# Altium schematic sheet RF.SchDoc(RF)
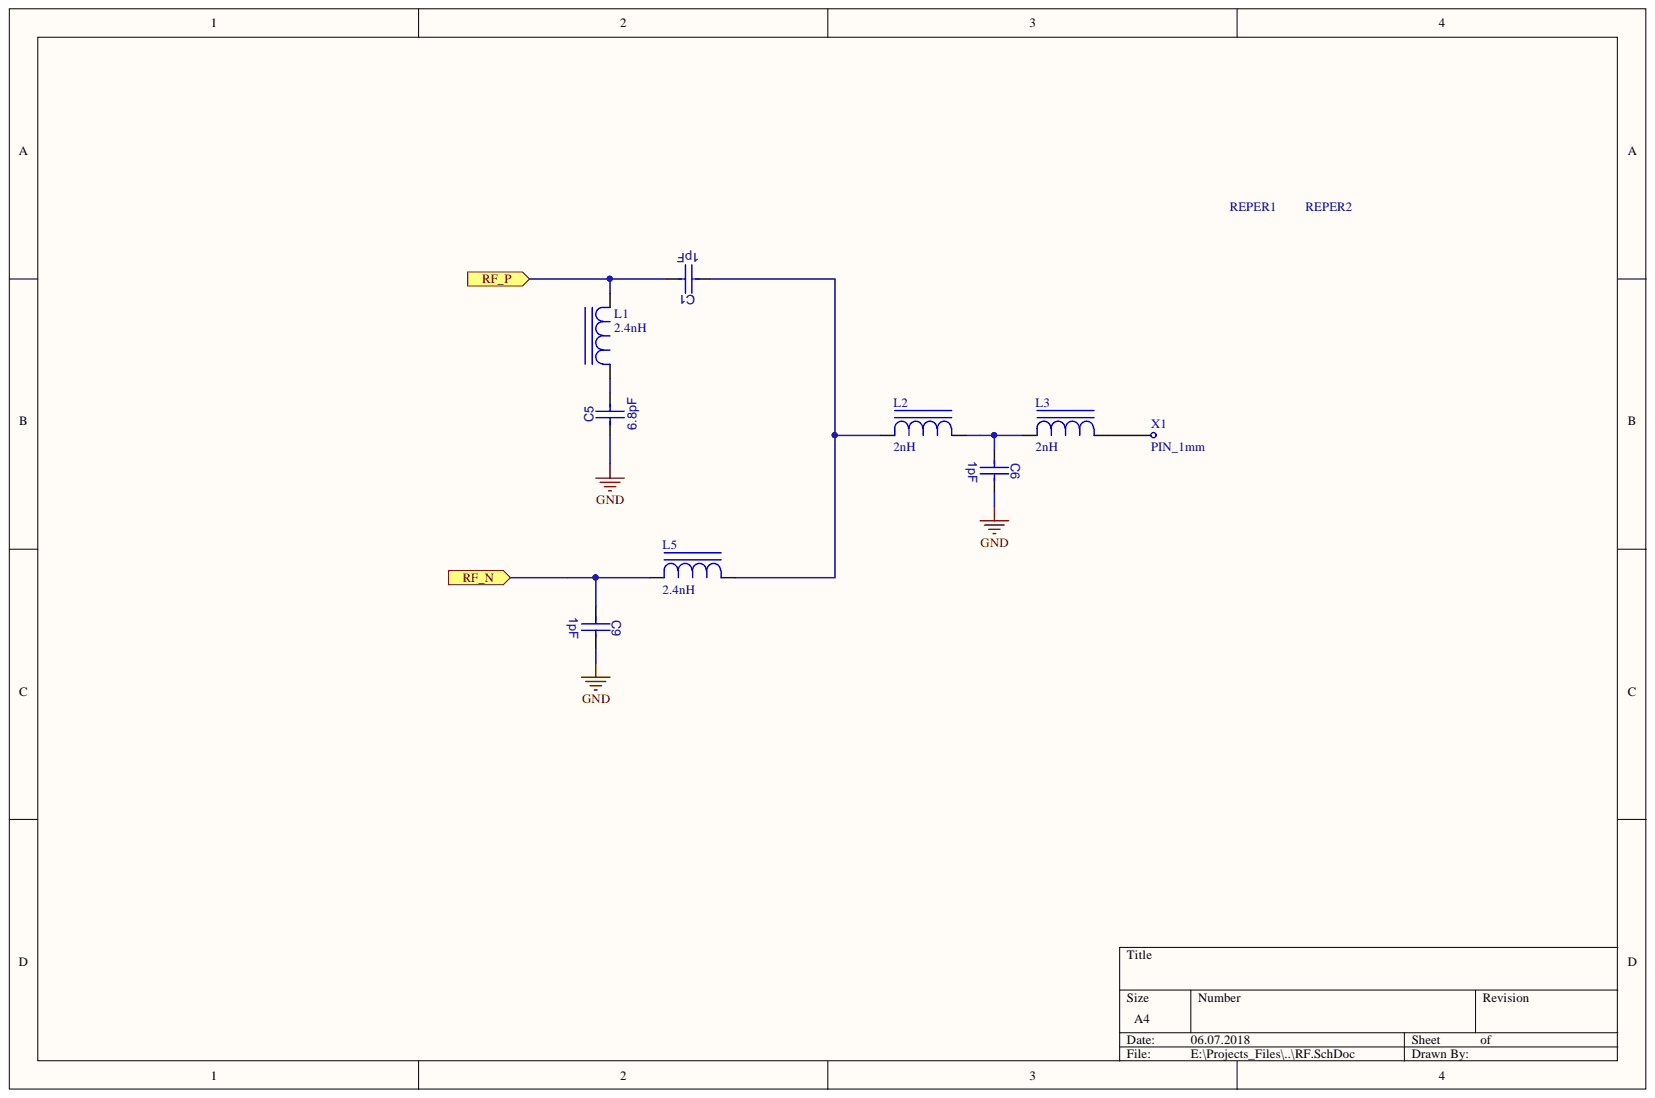
[diagram p0: RF.SchDoc]

## Parts
C1  1pF  [CC0603]
C5  6.8pF  [CC0603]
C6  1pF  [CC0603]
C9  1pF  [CC0603]
L1  2.4nH  [L0402]
L2  2nH  [L0402]
L3  2nH  [L0402]
L5  2.4nH  [L0402]
REPER1  REPER1.2  [REPER1.2]  (value not drawn)
REPER2  REPER1.2  [REPER1.2]  (value not drawn)
X1  PIN_1mm  [PIN_1mm]

## Ports
RF_N, RF_P

## Nets
GND: C5.1 C6.2 C9.2
RF_N: C9.1 L5.1 ; port RF_N
RF_P: C1.2 L1.2 ; port RF_P
NetC1_1: C1.1 L2.1 L5.2
NetC5_2: C5.2 L1.1
NetC6_1: C6.1 L2.2 L3.1
NetL3_2: L3.2 X1.1

## Library data of the parts
C1 C5 C6 C9 = CC0603: Description: CC0603; Component Kind: Standard; Library Name: gprs_modem_2.SchLib
  C1 C6 C9: Value: 1pF
  C5: Value: 6.8pF
L1-L3 L5 = L0402: Description: L0402; Component Kind: Standard; Library Name: gprs_modem_2.SchLib
  L1 L5: Value: 2.4nH
  L2 L3: Value: 2nH
REPER1 REPER2 = REPER1.2: Description: REPER1.2; Component Kind: Standard; Library Name: gprs_modem_2.SchLib
X1 = PIN_1mm: Component Kind: Standard; Library Name: gprs_modem_2.SchLib
